# Altium schematic sheet Micro.SchDoc(Micro)
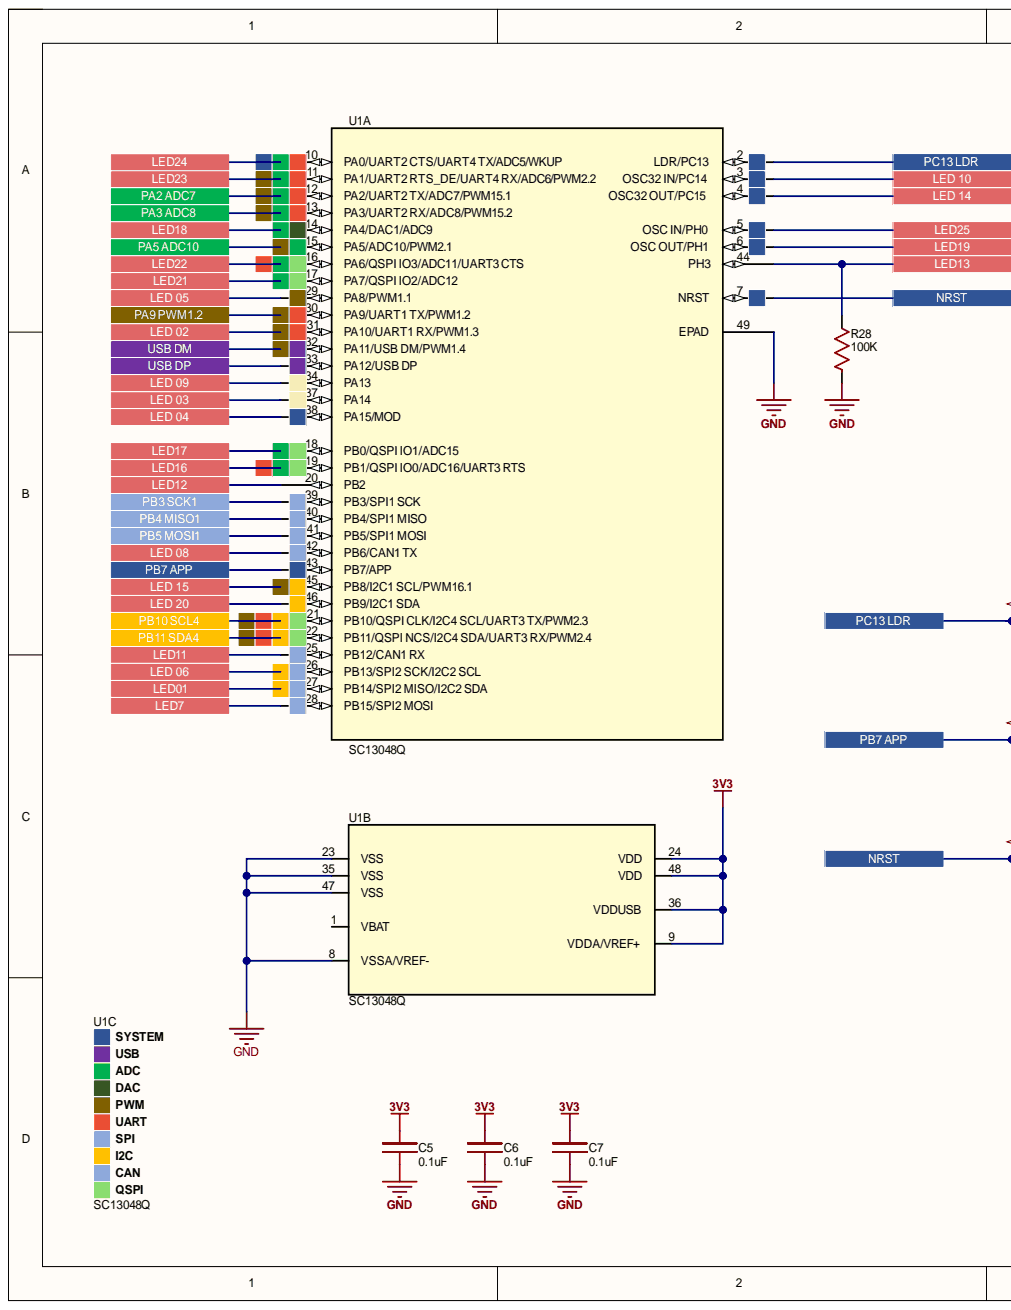
[diagram p0: Micro.SchDoc, left]
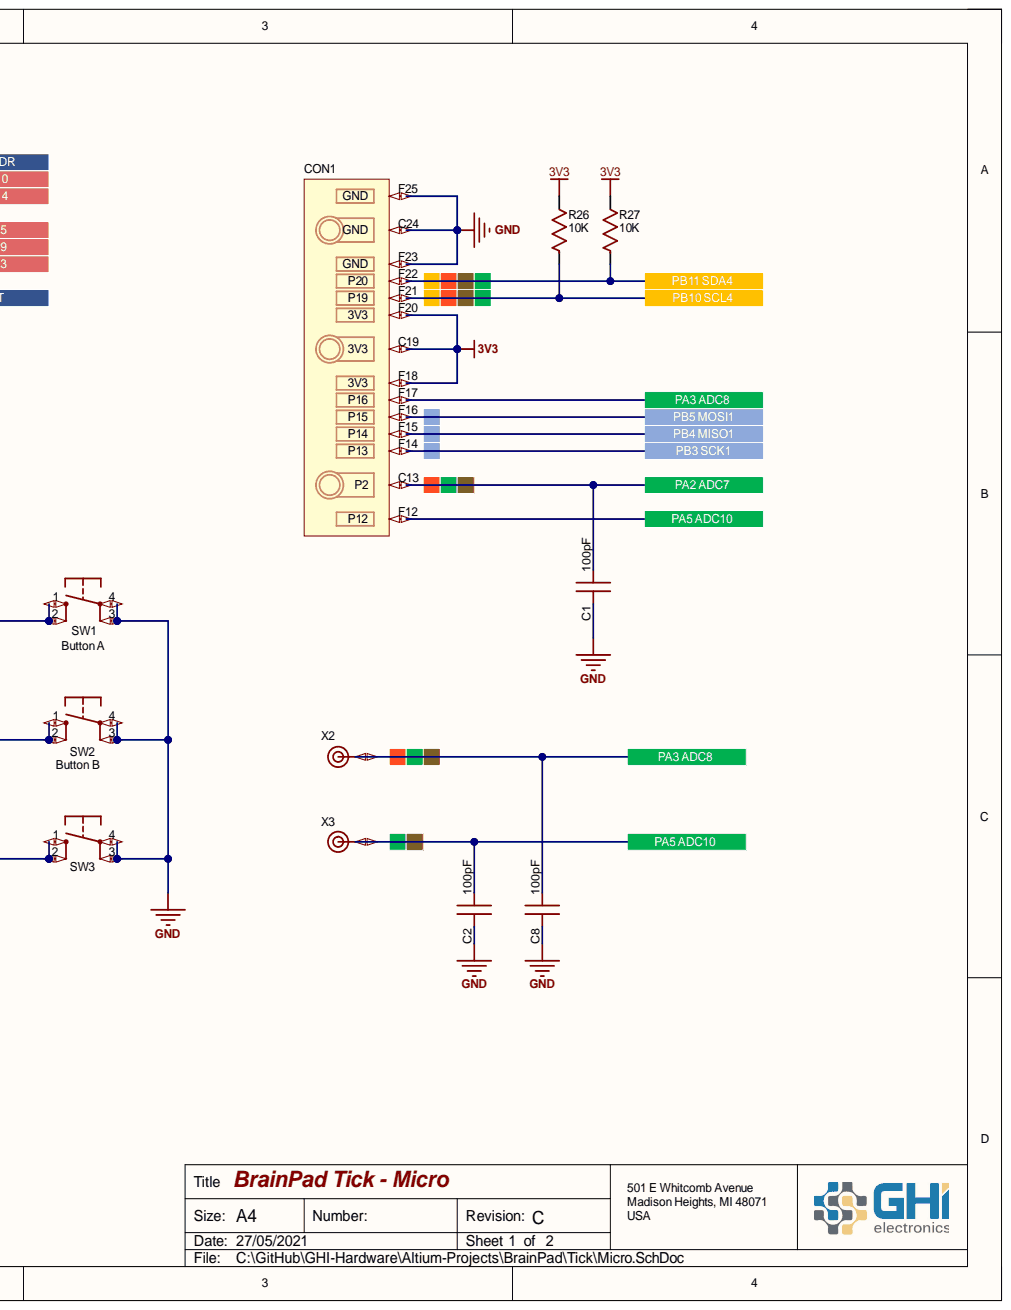
[diagram p1: Micro.SchDoc, right]

## Parts
C1  value 100pF  Capacitance: 100pF  [C0402]  (hidden comment: 0402 Cap 100pF)
C2  value 100pF  Capacitance: 100pF  [C0402]  (hidden comment: 0402 Cap 100pF)
C5  value 0.1uF  Capacitance: 0.1uF  [C0402]  (hidden comment: 0402 Cap 0.1uF)
C6  value 0.1uF  Capacitance: 0.1uF  [C0402]  (hidden comment: 0402 Cap 0.1uF)
C7  value 0.1uF  Capacitance: 0.1uF  [C0402]  (hidden comment: 0402 Cap 0.1uF)
C8  value 100pF  Capacitance: 100pF  [C0402]  (hidden comment: 0402 Cap 100pF)
CON1  BIT-EDGE-X  [BIT-EDGE-X - Tick]  (value not drawn)
R26  value 10K  Resistance: 10K  [R0402]  (hidden comment: 0402 Res 10K)
R27  value 10K  Resistance: 10K  [R0402]  (hidden comment: 0402 Res 10K)
R28  value 100K  Resistance: 100K  [R0402]  (hidden comment: 0402 Res 100K)
SW1  TVAU10-025CB-R  [TVAU10-025CB-R]  (value not drawn)
SW2  TVAU10-025CB-R  [TVAU10-025CB-R]  (value not drawn)
SW3  TVAU10-025CB-R  [TVAU10-025CB-R]  (value not drawn)
U1  SC13048Q  [UFQFPN48-7x7mm_STM]  (parts A,B)
U1C  SC13048Q  [UFQFPN48-7x7mm_STM]
X2  BIT-C-PAD  [BIT-C-PAD]  (value not drawn)
X3  BIT-C-PAD  [BIT-C-PAD]  (value not drawn)

## Ports
LED01, LED7, LED11, LED12, LED13, LED16, LED17, LED18, LED19, LED21, LED22, LED23, LED24, LED25, LED 02, LED 03, LED 04, LED 05, LED 06, LED 08, LED 09, LED 10, LED 14, LED 15, LED 20, NRST, PA2 ADC7, PA3 ADC8, PA5 ADC10, PA9 PWM1.2, PB3 SCK1, PB4 MISO1, PB5 MOSI1, PB7 APP, PB10 SCL4, PB11 SDA4, PC13 LDR, USB DM, USB DP

## Nets
3V3: C5.2 C6.2 C7.2 CON1.C19(3V3) CON1.F18(3V3) CON1.F20(3V3) R26.2 R27.2 U1.9(VDDA/VREF+) U1.24(VDD) U1.36(VDDUSB) U1.48(VDD)
GND: C1.1 C2.1 C5.1 C6.1 C7.1 C8.1 CON1.C24(GND) CON1.F23(GND) CON1.F25(GND) R28.1 SW1.3 SW1.4 SW2.3 SW2.4 SW3.3 SW3.4 U1.8(VSSA/VREF-) U1.23(VSS) U1.35(VSS) U1.47(VSS) U1.49(EPAD)
LED01: U1.27(PB14/SPI2_MISO/I2C2_SDA) ; port LED01
LED7: U1.28(PB15/SPI2_MOSI) ; port LED7
LED11: U1.25(PB12/CAN1_RX) ; port LED11
LED12: U1.20(PB2) ; port LED12
LED13: R28.2 U1.44(PH3) ; port LED13
LED16: U1.19(PB1/QSPI_IO0/ADC16/UART3_RTS) ; port LED16
LED17: U1.18(PB0/QSPI_IO1/ADC15) ; port LED17
LED18: U1.14(PA4/DAC1/ADC9) ; port LED18
LED19: U1.6(OSC_OUT/PH1) ; port LED19
LED21: U1.17(PA7/QSPI_IO2/ADC12) ; port LED21
LED22: U1.16 ; port LED22
LED23: U1.11 ; port LED23
LED24: U1.10 ; port LED24
LED25: U1.5(OSC_IN/PH0) ; port LED25
LED 02: U1.31(PA10/UART1_RX/PWM1.3) ; port LED 02
LED 03: U1.37(PA14) ; port LED 03
LED 04: U1.38(PA15/MOD) ; port LED 04
LED 05: U1.29(PA8/PWM1.1) ; port LED 05
LED 06: U1.26(PB13/SPI2_SCK/I2C2_SCL) ; port LED 06
LED 08: U1.42(PB6/CAN1_TX) ; port LED 08
LED 09: U1.34(PA13) ; port LED 09
LED 10: U1.3(OSC32_IN/PC14) ; port LED 10
LED 14: U1.4(OSC32_OUT/PC15) ; port LED 14
LED 15: U1.45(PB8/I2C1_SCL/PWM16.1) ; port LED 15
LED 20: U1.46(PB9/I2C1_SDA) ; port LED 20
NRST: SW3.1 SW3.2 U1.7(NRST) ; port NRST
PA2 ADC7: C1.2 CON1.C13(P2) U1.12(PA2/UART2_TX/ADC7/PWM15.1) ; port PA2 ADC7
PA3 ADC8: C8.2 CON1.F17(P16) U1.13(PA3/UART2_RX/ADC8/PWM15.2) X2.1 ; port PA3 ADC8
PA5 ADC10: C2.2 CON1.F12(P12) U1.15(PA5/ADC10/PWM2.1) X3.1 ; port PA5 ADC10
PA9 PWM1.2: U1.30(PA9/UART1_TX/PWM1.2) ; port PA9 PWM1.2
PB3 SCK1: CON1.F14(P13) U1.39(PB3/SPI1_SCK) ; port PB3 SCK1
PB4 MISO1: CON1.F15(P14) U1.40(PB4/SPI1_MISO) ; port PB4 MISO1
PB5 MOSI1: CON1.F16(P15) U1.41(PB5/SPI1_MOSI) ; port PB5 MOSI1
PB7 APP: SW2.1 SW2.2 U1.43(PB7/APP) ; port PB7 APP
PB10 SCL4: CON1.F21(P19) R26.1 U1.21(PB10/QSPI_CLK/I2C4_SCL/UART3_TX/PWM2.3) ; port PB10 SCL4
PB11 SDA4: CON1.F22(P20) R27.1 U1.22(PB11/QSPI_NCS/I2C4_SDA/UART3_RX/PWM2.4) ; port PB11 SDA4
PC13 LDR: SW1.1 SW1.2 U1.2(LDR/PC13) ; port PC13 LDR
USB DM: U1.32(PA11/USB_DM/PWM1.4) ; port USB DM
USB DP: U1.33(PA12/USB_DP) ; port USB DP

Not connected: U1 1(VBAT)

## Library data of the parts
C1 C2 C8 = 0402 Cap Generic: Generic ±10% 16V X7R 0402 Multilayer Ceramic Capacitors MLCC - SMD/SMT RoHS; Category: Ceramic; Component Kind: Standard; Package: 0402; Tolerance: ±10%; Library Name: GHI-Passives.SchLib
C5-C7 = 0402 Cap 0.1uF: 0.1uF ±10% 16V X7R 0402 Multilayer Ceramic Capacitors MLCC - SMD/SMT RoHS; Mfr.Part #: CC0402KRX7R7BB104; Category: Ceramic; Component Kind: Standard; LCSC Part #: C60474; Package: 0402; Tolerance: ±10%; Voltage: 16V; Library Name: GHI-Passives.SchLib
CON1 = BIT-EDGE-Mini: BIT is the complete dimension with the edge. BIT-EDGE is only the edge connector of the BIT. BIT-EDGE-X is a simplified pad configuration for the BIT.; GateName_1: G$1; Component Kind: Standard; DeviceName: -EDGE-X; DeviceSetName: BIT; SymbolName_1: BIT; Library Name: GHI-Connectors.SchLib; LibraryName: GHI-Solutions
R26 R27 = 0402 Res 10K: 10K ±1% 1/16W ±100ppm/℃ 0402 Chip Resistor - Surface Mount RoHS; Manufacturer: YAGEO; Mfr.Part #: RC0402FR-0710KL; Voltage Rating: *V; Component Kind: Standard; LCSC Part #: C60490; Package: 0402; Power Rating: 1/16W; Resistance Tolerance: ±1%; Library Name: GHI-Passives.SchLib
R28 = 0402 Res 10K: 100K ±1% 1/16W ±100ppm/℃ 0402 Chip Resistor - Surface Mount RoHS; Manufacturer: YAGEO; Voltage Rating: *V; Component Kind: Standard; Package: 0402; Power Rating: 1/16W; Resistance Tolerance: ±1%; Library Name: GHI-Passives.SchLib
SW1-SW3 = 4.2x3.2 Tactile Swith: Value: TVDJ04-G73; Component Kind: Standard; Library Name: GHI-Mechanical.SchLib
U1 U1C = SC13048Q: No Description Available; Component Kind: Standard; Value: SC13048Q; Library Name: GHI-Integrated Circuits.SchLib
X2 X3 = BIT-C-PAD: GateName_1: G$1; Component Kind: Standard; DeviceSetName: BIT-C-PAD; SymbolName_1: BIT-C-PAD; Library Name: GHI-Connectors.SchLib; LibraryName: GHI-SpecialPads
